# Altium schematic sheet TailLightPrototype.SchDoc(TailLightPrototype)
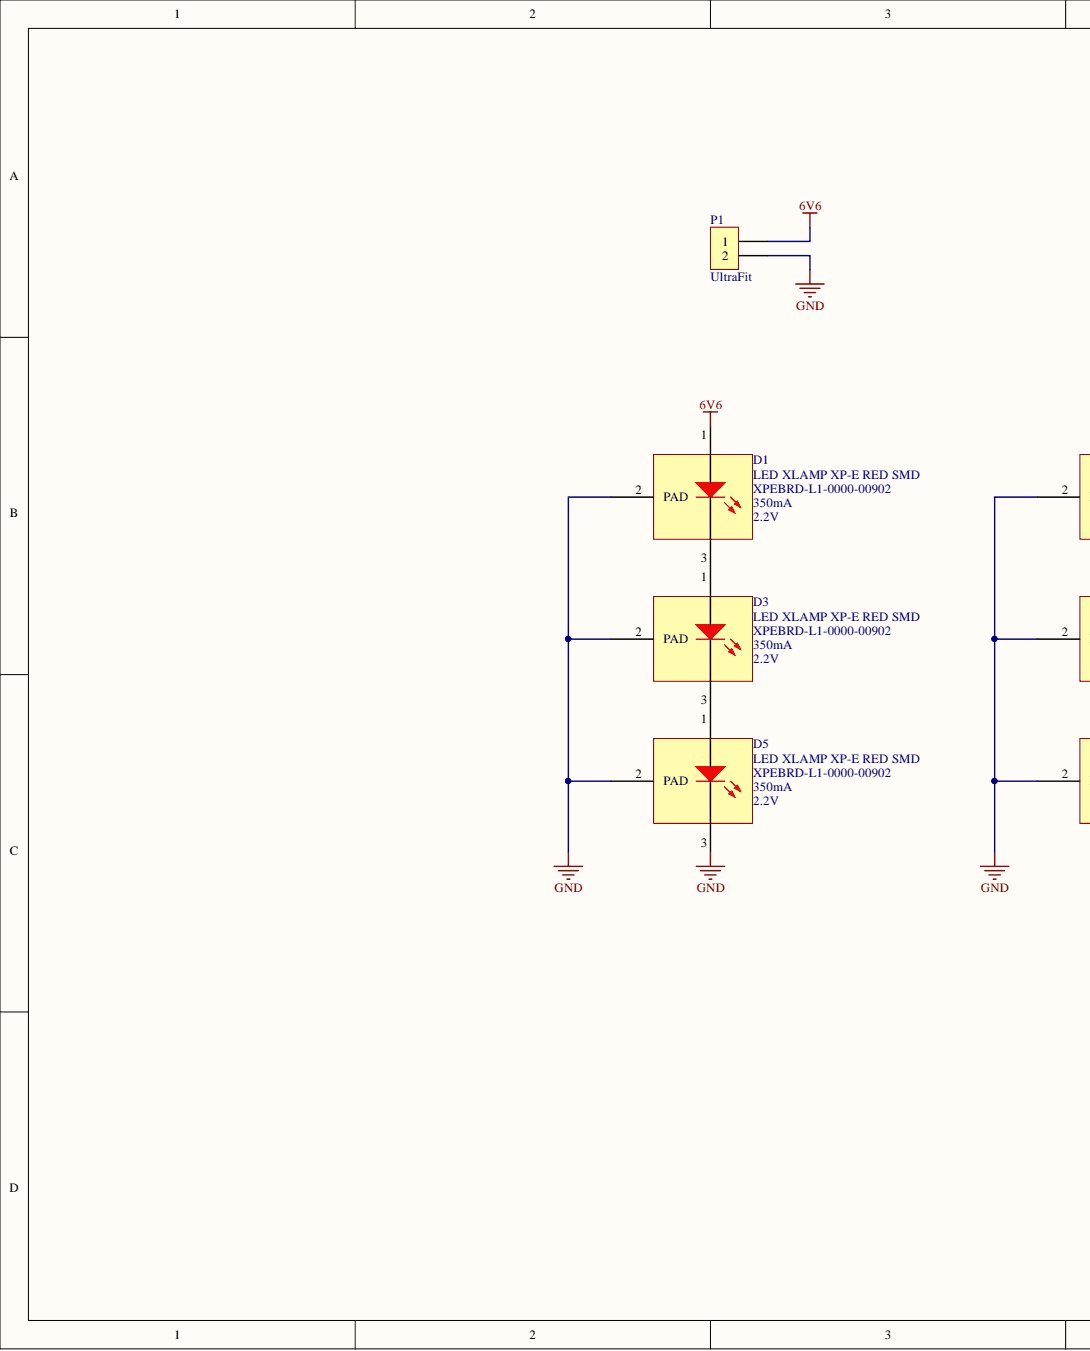
[diagram p0: TailLightPrototype.SchDoc, left]
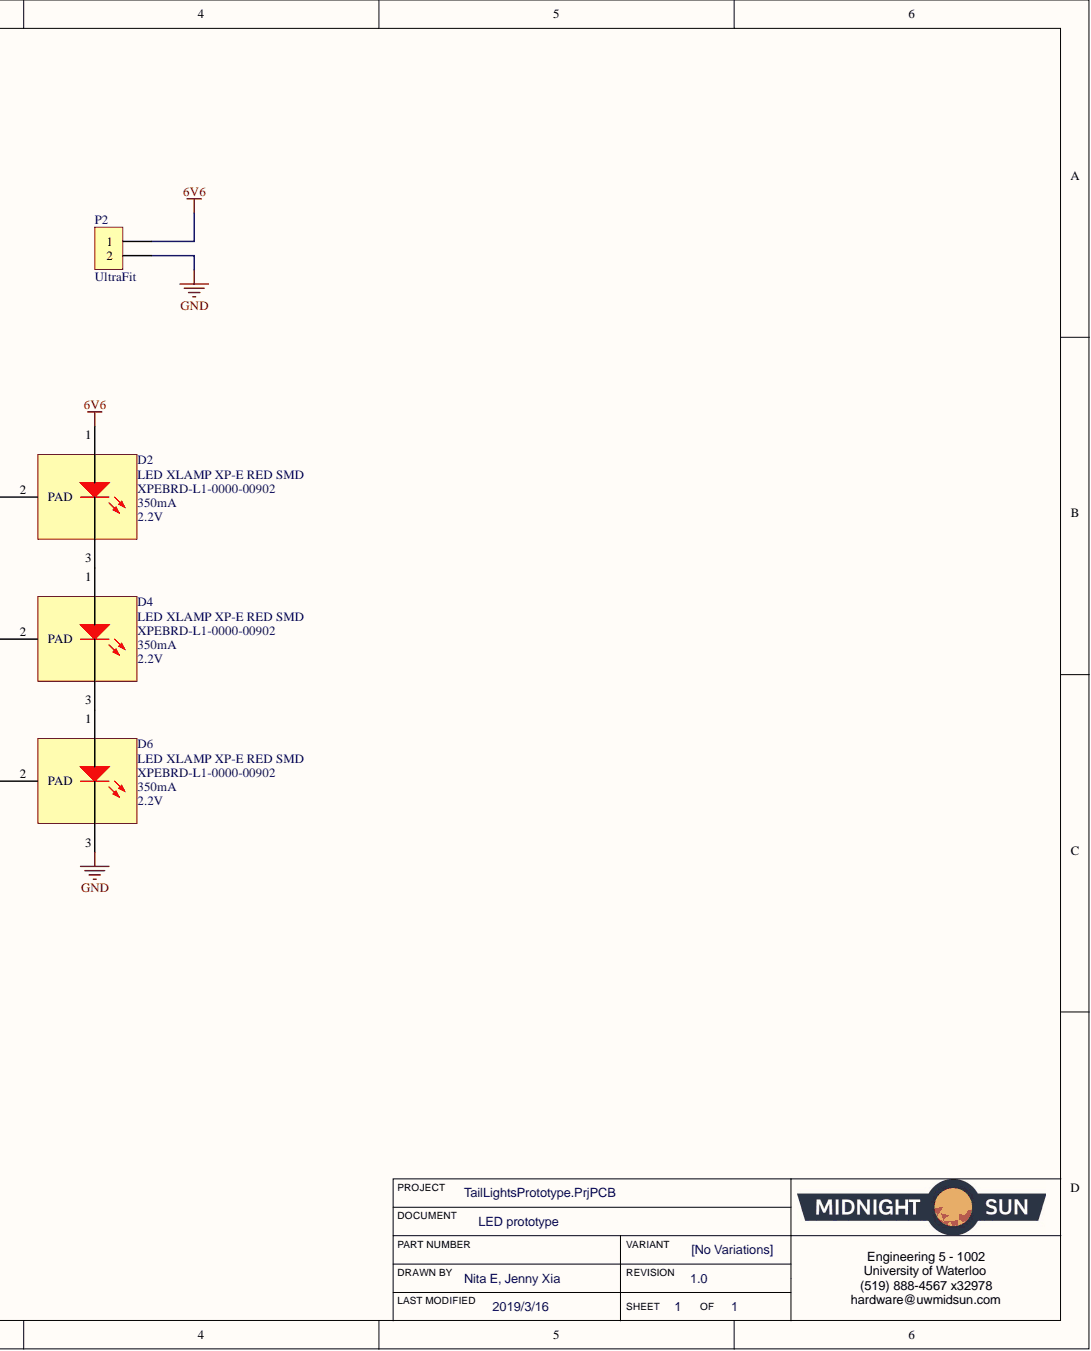
[diagram p1: TailLightPrototype.SchDoc, right]

## Parts
D1  Test Current: 350mA  Forward Voltage: 2.2V  [LED CREE XP-E2 1414 2-SMD]  (hidden comment: LED XLAMP XPE2 AMBER 593NM 2SMD)
D1  Test Current: 350mA  [LED CREE XP-E2 1414 2-SMD]  (hidden comment: LED XLAMP COOL WHITE 5700K 2SMD)
D1  LED XLAMP XP-E RED SMD  Test Current: 350mA  Manufacturer Part Number: XPEBRD-L1-0000-00902  Forward Voltage: 2.2V  [LED CREE XP-E2 1414 2-SMD]
D2  Test Current: 350mA  Forward Voltage: 2.2V  [LED CREE XP-E2 1414 2-SMD]  (hidden comment: LED XLAMP XPE2 AMBER 593NM 2SMD)
D2  Test Current: 350mA  [LED CREE XP-E2 1414 2-SMD]  (hidden comment: LED XLAMP COOL WHITE 5700K 2SMD)
D2  LED XLAMP XP-E RED SMD  Test Current: 350mA  Manufacturer Part Number: XPEBRD-L1-0000-00902  Forward Voltage: 2.2V  [LED CREE XP-E2 1414 2-SMD]
D3  Test Current: 350mA  Forward Voltage: 2.2V  [LED CREE XP-E2 1414 2-SMD]  (hidden comment: LED XLAMP XPE2 AMBER 593NM 2SMD)
D3  Test Current: 350mA  [LED CREE XP-E2 1414 2-SMD]  (hidden comment: LED XLAMP COOL WHITE 5700K 2SMD)
D3  LED XLAMP XP-E RED SMD  Test Current: 350mA  Manufacturer Part Number: XPEBRD-L1-0000-00902  Forward Voltage: 2.2V  [LED CREE XP-E2 1414 2-SMD]
D4  Test Current: 350mA  Forward Voltage: 2.2V  [LED CREE XP-E2 1414 2-SMD]  (hidden comment: LED XLAMP XPE2 AMBER 593NM 2SMD)
D4  Test Current: 350mA  [LED CREE XP-E2 1414 2-SMD]  (hidden comment: LED XLAMP COOL WHITE 5700K 2SMD)
D4  LED XLAMP XP-E RED SMD  Test Current: 350mA  Manufacturer Part Number: XPEBRD-L1-0000-00902  Forward Voltage: 2.2V  [LED CREE XP-E2 1414 2-SMD]
D5  Test Current: 350mA  Forward Voltage: 2.2V  [LED CREE XP-E2 1414 2-SMD]  (hidden comment: LED XLAMP XPE2 AMBER 593NM 2SMD)
D5  Test Current: 350mA  [LED CREE XP-E2 1414 2-SMD]  (hidden comment: LED XLAMP COOL WHITE 5700K 2SMD)
D5  LED XLAMP XP-E RED SMD  Test Current: 350mA  Manufacturer Part Number: XPEBRD-L1-0000-00902  Forward Voltage: 2.2V  [LED CREE XP-E2 1414 2-SMD]
D6  Test Current: 350mA  Forward Voltage: 2.2V  [LED CREE XP-E2 1414 2-SMD]  (hidden comment: LED XLAMP XPE2 AMBER 593NM 2SMD)
D6  Test Current: 350mA  [LED CREE XP-E2 1414 2-SMD]  (hidden comment: LED XLAMP COOL WHITE 5700K 2SMD)
D6  LED XLAMP XP-E RED SMD  Test Current: 350mA  Manufacturer Part Number: XPEBRD-L1-0000-00902  Forward Voltage: 2.2V  [LED CREE XP-E2 1414 2-SMD]
P1  Series: UltraFit  [CONN, 2POS ULTRA-FIT]  (hidden comment: CONN 2POS ULTRA-FIT 0.138")
P2  Series: UltraFit  [CONN, 2POS ULTRA-FIT]  (hidden comment: CONN 2POS ULTRA-FIT 0.138")

## Nets
6V6: D1.1 D2.1 P1.1 P2.1
GND: D1.2 D2.2 D3.2 D4.2 D5.2 D5.3 D6.2 D6.3 P1.2 P2.2
NetD1_3: D1.3 D3.1
NetD2_3: D2.3 D4.1
NetD3_3: D3.3 D5.1
NetD4_3: D4.3 D6.1

## Library data of the parts
D1-D6 = LED XLAMP XPE2 AMBER 593NM 2SMD: LED Lighting Color XLamp® XP-E2 Amber 593nm (590nm ~ 595nm) 1414 (3535 Metric); Manufacturer Part Number: XPEBAM-L1-0000-00801; Manufacturer: Cree; Viewing Angle: 130°; Thermal Resistance of Package: 7°C/W; Test Temperature: 25°C; Reverse Voltage (DC): 5V; Power Rating: 3W; Number of Elements: 1; Max Current Rating: 1A; Luminous Flux: 73.9lm; Lens Style: Dome; Lens Color: Clear; Illumination Color: Orange; Width: 3.45mm; Wavelength: 595nm; Supplier Part Number 1: XPEBAM-L1-0000-00801CT-ND; Supplier 1: Digi-Key; Stock 1: 4413; RoHS: Yes; Reverse Voltage: 5V; Pricing 1: 1 = 1.60000, 10 = 1.51600, 100 = 1.35640, 250 = 1.23356, 500 = 1.15536 (USD); Packaging: Tape and Reel; Package Quantity: 1000; Number of Pins: 2; Mount: Surface Mount; Max Operating Temperature: 150°C; Length: 3.45mm; Lead Free: Lead Free; Height: 2.26mm; Forward Current: 350mA; Dominant Wavelength: 595nm; Depth: 3.45mm; ComponentLink2URL: http://www.mouser.com/ds/2/90/XLampXPE2-253473.pdf?src-supplier=Mouser; ComponentLink2Description: http://www.mouser.com/ds/2/90/XLampXPE2-253473.pdf?src-supplier=Mouser; ComponentLink1URL: http://www.cree.com/~/media/Files/Cree/LED%20Components%20and%20Modules/XLamp/Data%20and%20Binning/XLampXPE2.pdf?src-supplier=Digi-Key; ComponentLink1Description: http://www.cree.com/~/media/Files/Cree/LED%20Components%20and%20Modules/XLamp/Data%20and%20Binning/XLampXPE2.pdf?src-supplier=Digi-Key; Component Kind: Standard; Color: Orange; Case/Package: SMD/SMT; Library Name: Schematic Diagrams.SchLib
D1-D6 = LED XLAMP COOL WHITE 5700K 2SMD: LED Lighting XLamp® XP-E2 White, Cool 5700K 2.9V 350mA 110° 1414 (3535 Metric); Manufacturer Part Number: XPGBWT-L1-0000-00JE2; Manufacturer: Cree; Viewing Angle: 115°; Thermal Resistance of Package: 4°C/W; Max Current Rating: 1.5A; Forward Voltage: 2.8V; Width: 3.45mm; Supplier Part Number 1: XPGBWT-L1-0000-00JE2CT-ND; Supplier 1: Digi-Key; Stock 1: 6024; RoHS: Yes; Pricing 1: 1 = 1.47000, 10 = 1.40100, 100 = 1.25340, 250 = 1.13988, 500 = 1.06762 (USD); Packaging: Tape and Reel; Mount: Surface Mount; Length: 3.45mm; Lead Free: Lead Free; Correlated Color Temperature (CCT): 5700K; ComponentLink3URL: https://www.verical.com/server-webapp/html/getDatasheet?partID=702662&src-supplier=Verical; ComponentLink3Description: https://www.verical.com/server-webapp/html/getDatasheet?partID=702662&src-supplier=Verical; ComponentLink2URL: http://www.cree.com/~/media/Files/Cree/LED%20Components%20and%20Modules/XLamp/Data%20and%20Binning/XLampXPE2.pdf?src-supplier=Digi-Key; ComponentLink2Description: http://www.cree.com/~/media/Files/Cree/LED%20Components%20and%20Modules/XLamp/Data%20and%20Binning/XLampXPE2.pdf?src-supplier=Digi-Key; ComponentLink1URL: http://www.mouser.com/ds/2/90/XLampXPE2-253473.pdf?src-supplier=Mouser; ComponentLink1Description: http://www.mouser.com/ds/2/90/XLampXPE2-253473.pdf?src-supplier=Mouser; Component Kind: Standard; Color: White; Case/Package: SMD/SMT; Library Name: Schematic Diagrams.SchLib
D1-D6 = LED XLAMP XP-E RED SMD: LED Lighting Color XLamp® XP-E2 Red 623nm (620nm ~ 625nm) 1414 (3535 Metric); Manufacturer: Cree; Viewing Angle: 130°; Thermal Resistance of Package: 5°C/W; Test Temperature: 25°C; Max Current Rating: 1A; Wavelength: 625nm; Supplier Part Number 1: XPEBRD-L1-0000-00902CT-ND; Supplier 1: Digi-Key; Stock 1: 1640; RoHS: Yes; Pricing 1: 1 = 2.00000, 10 = 1.90000, 100 = 1.69980, 250 = 1.54584, 500 = 1.44786 (USD); Packaging: Tape and Reel; Mount: Surface Mount; Lead Free: Lead Free; Component Kind: Standard; Color: Red; Case/Package: SMD/SMT; Library Name: Schematic Diagrams.SchLib
P1 P2 = CONN 2POS ULTRA-FIT 0.138": 2 Positions Header, Shrouded Connector 0.138" (3.50mm) Through Hole Gold; Manufacturer Part Number 1: 1722861302; Manufacturer 1: Molex, LLC; Voltage: 400V; Style: Board to Board or Cable; Shrouding: Shrouded - 4 Wall; Number of Positions Loaded: All; Number of Positions: 2; Material Flammability Rating: UL94 V-0; Mated Stacking Heights: 26.99mm; Contact Length - Post: 0.126" (3.20mm); Termination: Kinked Pin, Solder; Supplier Part Number 1: WM11673-ND; Supplier 1: Digi-Key; Row Spacing - Mating: -; Pitch - Mating: 0.138" (3.50mm); Part Status: Active; Packaging: Tray; Overall Contact Length: -; Operating Temperature: -40°C ~ 120°C; Number of Rows: 1; Mounting Type: Through Hole; Insulation Material: Liquid Crystal Polymer (LCP); Insulation Height: 0.465" (11.80mm); Insulation Color: Black; Ingress Protection: -; Fastening Type: Latch Holder; Current Rating: -; Contact Type: Male Pin; Contact Shape: Square; Contact Material: Copper Alloy; Contact Length - Mating: -; Contact Finish Thickness - Post: 60µin (1.52µm); Contact Finish Thickness - Mating: 30µin (0.76µm); Contact Finish - Post: Tin; Contact Finish - Mating: Gold; Connector Type: Header; ComponentLink1URL: http://www.molex.com/pdm_docs/sd/1722861302_sd.pdf; ComponentLink1Description: http://www.molex.com/pdm_docs/sd/1722861302_sd.pdf; Component Kind: Standard; Library Name: Schematic Diagrams.SchLib
